# Altium schematic sheet MS20_schematic.SchDoc(MS20_schematic)
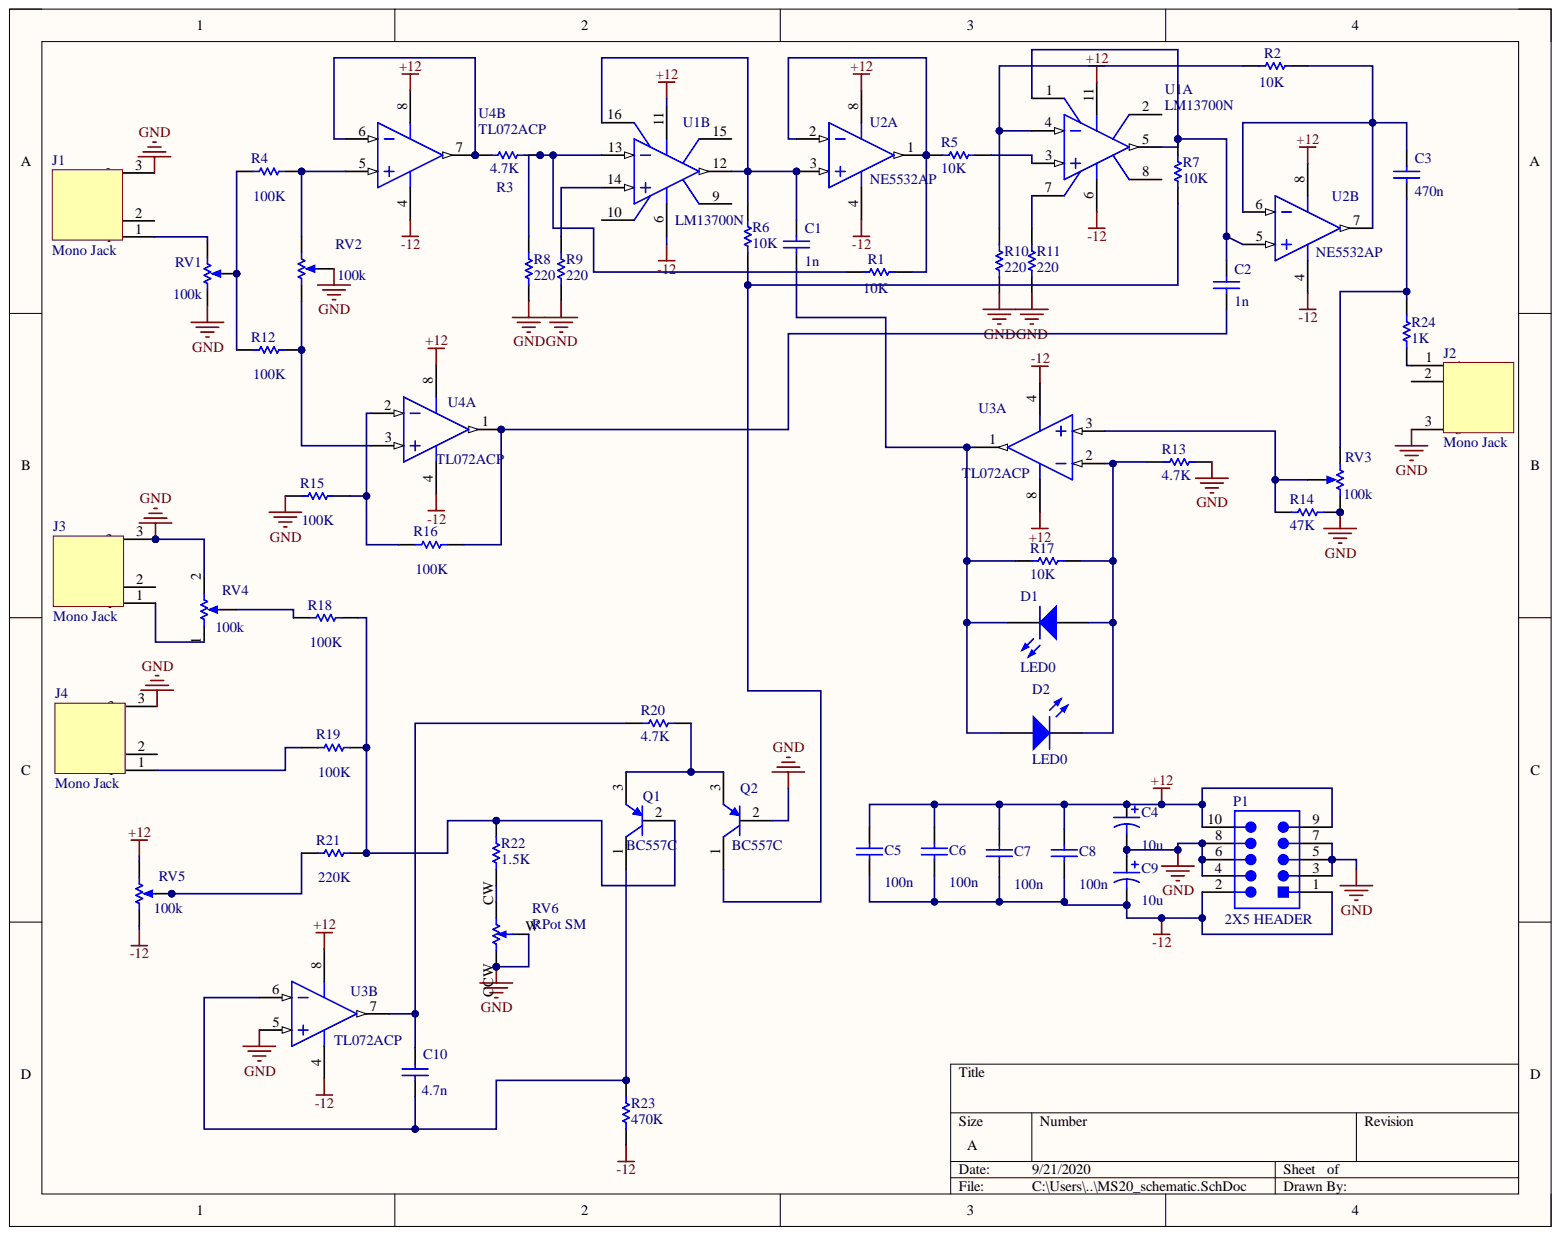
[diagram p0: MS20_schematic.SchDoc]

## Parts
C1  value 1n  [RAD-0.3]  (hidden comment: Cap)
C2  value 1n  [RAD-0.3]  (hidden comment: Cap)
C3  value 470n  [RAD-0.3]  (hidden comment: Cap)
C4  value 10u  [RB7.6-15]  (hidden comment: Cap Pol1)
C5  value 100n  [RAD-0.3]  (hidden comment: Cap)
C6  value 100n  [RAD-0.3]  (hidden comment: Cap)
C7  value 100n  [RAD-0.3]  (hidden comment: Cap)
C8  value 100n  [RAD-0.3]  (hidden comment: Cap)
C9  value 10u  [RB7.6-15]  (hidden comment: Cap Pol1)
C10  value 4.7n  [RAD-0.3]  (hidden comment: Cap)
D1  LED0  [LED-0]
D2  LED0  [LED-0]
J1  Mono Jack  [MONO_Jack]
J2  Mono Jack  [MONO_Jack]
J3  Mono Jack  [MONO_Jack]
J4  Mono Jack  [MONO_Jack]
P1  2X5 HEADER  [TECO-1-1634688-0-10_V]
Q1  BC557C  [ONSC-TO-92-3-29-11]
Q2  BC557C  [ONSC-TO-92-3-29-11]
R1  value 10K  [AXIAL-0.3]  (hidden comment: Res1)
R2  value 10K  [AXIAL-0.3]  (hidden comment: Res1)
R3  value 4.7K  [AXIAL-0.3]  (hidden comment: Res1)
R4  value 100K  [AXIAL-0.3]  (hidden comment: Res1)
R5  value 10K  [AXIAL-0.3]  (hidden comment: Res1)
R6  value 10K  [AXIAL-0.3]  (hidden comment: Res1)
R7  value 10K  [AXIAL-0.3]  (hidden comment: Res1)
R8  value 220  [AXIAL-0.3]  (hidden comment: Res1)
R9  value 220  [AXIAL-0.3]  (hidden comment: Res1)
R10  value 220  [AXIAL-0.3]  (hidden comment: Res1)
R11  value 220  [AXIAL-0.3]  (hidden comment: Res1)
R12  value 100K  [AXIAL-0.3]  (hidden comment: Res1)
R13  value 4.7K  [AXIAL-0.3]  (hidden comment: Res1)
R14  value 47K  [AXIAL-0.3]  (hidden comment: Res1)
R15  value 100K  [AXIAL-0.3]  (hidden comment: Res1)
R16  value 100K  [AXIAL-0.3]  (hidden comment: Res1)
R17  value 10K  [AXIAL-0.3]  (hidden comment: Res1)
R18  value 100K  [AXIAL-0.3]  (hidden comment: Res1)
R19  value 100K  [AXIAL-0.3]  (hidden comment: Res1)
R20  value 4.7K  [AXIAL-0.3]  (hidden comment: Res1)
R21  value 220K  [AXIAL-0.3]  (hidden comment: Res1)
R22  value 1.5K  [AXIAL-0.3]  (hidden comment: Res1)
R23  value 470K  [AXIAL-0.3]  (hidden comment: Res1)
R24  value 1K  [AXIAL-0.3]  (hidden comment: Res1)
RV1  value 100k  [VR5]  (hidden comment: RPot)
RV2  value 100k  [VR5]  (hidden comment: RPot)
RV3  value 100k  [VR5]  (hidden comment: RPot)
RV4  value 100k  [VR5]  (hidden comment: RPot)
RV5  value 100k  [VR5]  (hidden comment: RPot)
RV6  RPot SM  [POT4MM-2]
U1  LM13700N  [NFG0016A]  (parts A,B)
U2  NE5532AP  [P0008A]  (parts A,B)
U3  TL072ACP  [P0008A]  (parts A,B)
U4  TL072ACP  [P0008A]  (parts A,B)

## Nets
+12: C4.1 C5.1 C6.1 C7.1 C8.1 P1.9 P1.10 RV5.2 U1.11 U2.8 U3.8 U4.8
-12: C5.2 C6.2 C7.2 C8.2 C9.2 P1.1 P1.2 R23.2 RV5.1 U1.6 U2.4 U3.4 U4.4
GND: C4.2 C9.1 J1.3 J2.3 J3.3 J4.3 P1.3 P1.4 P1.5 P1.6 P1.7 P1.8 Q2.2 R8.1 R9.1 R10.1 R11.1 R13.1 R14.1 R15.1 RV1.1 RV2.3 RV3.2 RV4.2 RV6.CCW RV6.W U3.5
NetC1_1: C1.1 R6.2 U1.12 U1.16 U2.3
NetC1_2: C1.2 D1.2 D2.1 R17.2 U3.1
NetC2_1: C2.1 R7.2 U1.1 U1.5 U2.5
NetC2_2: C2.2 R16.2 U4.1
NetC3_1: C3.1 R2.1 U2.6 U2.7
NetC3_2: C3.2 R24.2 RV3.1
NetC10_1: C10.1 R20.2 U3.7
NetC10_2: C10.2 Q1.1 R23.1 U3.6
NetD1_1: D1.1 D2.2 R13.2 R17.1 U3.2
NetJ1_1: J1.1 RV1.2
NetJ2_1: J2.1 R24.1
NetJ3_1: J3.1 RV4.1
NetJ4_1: J4.1 R19.1
NetQ1_2: Q1.2 R18.2 R19.2 R21.2 R22.2
NetQ1_3: Q1.3 Q2.3 R20.1
NetQ2_1: Q2.1 R6.1 R7.1
NetR1_1: R1.1 R5.2 U2.1 U2.2
NetR1_2: R1.2 R3.1 R8.2 U1.13
NetR2_2: R2.2 R10.2 U1.4
NetR3_2: R3.2 U4.6 U4.7
NetR4_1: R4.1 R12.1 RV1.3
NetR4_2: R4.2 RV2.2 U4.5
NetR5_1: R5.1 U1.3
NetR9_2: R9.2 U1.14
NetR11_2: R11.2 U1.7
NetR12_2: R12.2 RV2.1 U4.3
NetR14_2: R14.2 RV3.3 U3.3
NetR15_2: R15.2 R16.1 U4.2
NetR18_1: R18.1 RV4.3
NetR21_1: R21.1 RV5.3
NetR22_1: R22.1 RV6.CW

Not connected: J1 2; J2 2; J3 2; J4 2; U1 2 8 9 10 15

## Library data of the parts
C1-C3 C5-C8 C10 = Cap: Capacitor; Component Kind: Standard; LatestRevisionDate: 17-Jul-2002; LatestRevisionNote: Re-released for DXP Platform.; Library Name: Miscellaneous Devices.IntLib; PackageDescription: Radial Cap, Thru-Hole; 2 Leads; 0.3 in Pin Spacing; PackageReference: RAD-0.3; Published: 8-Jun-2000; Signal Integrity: Cap; Simulation: CAP; Publisher: Altium Limited
C4 C9 = Cap Pol1: Polarized Capacitor (Radial); Component Kind: Standard; LatestRevisionDate: 17-Jul-2002; LatestRevisionNote: Re-released for DXP Platform.; Library Name: Miscellaneous Devices.IntLib; PackageDescription: Polarized Capacitor (Radial); 2 Leads; PackageReference: RB7.6-15; Published: 8-Jun-2000; Signal Integrity: Cap Pol; Simulation: CAP; Publisher: Altium Limited
D1 D2 = LED0: Typical INFRARED GaAs LED; Component Kind: Standard; LatestRevisionDate: 08-Jul-2005; LatestRevisionNote: Mech Layer 1 removed.; Library Name: Miscellaneous Devices.IntLib; PackageDescription: LED; 2 Leads; PackageReference: LED-0; Published: 8-Jun-2000; Simulation: LED0; Publisher: Altium Limited
J1-J4 = 4832.2211: Phone Connectors Audio Socket 3.5MM 2P SCR; Manufacturer: Schurter; Body Material: Metal; Current Rating: 500mA; Gender: Female; Max Voltage Rating (AC): 30V; Number of Contacts: 2; Number of Ports: 1; Shielding: Shielded; Case/Package: Metal; Category: Audio / Video Connectors; Component Kind: Standard; ComponentLink1Description: https://datasheet.ciiva.com/25289/1580985-25289573.pdf?src-supplier=Newark; ComponentLink1URL: https://datasheet.ciiva.com/25289/1580985-25289573.pdf?src-supplier=Newark; ComponentLink2Description: https://datasheet.ciiva.com/12540/typ-4832-2211-58787-12540719.pdf?src-supplier=Mouser; ComponentLink2URL: https://datasheet.ciiva.com/12540/typ-4832-2211-58787-12540719.pdf?src-supplier=Mouser; ComponentLink3Description: https://datasheet.ciiva.com/1086/1580985-1086284.pdf?src-supplier=Element14; ComponentLink3URL: https://datasheet.ciiva.com/1086/1580985-1086284.pdf?src-supplier=Element14; Contact Plating: Silver; Depth: 9mm; Diameter: 3.5mm; Length: 10.5mm; Library Name: Schlib1.SchLib; Manufacturer Part Number: 4832.2211; Material: Plastic; Max Current Rating: 500mA; Max Operating Temperature: 70°C; Min Operating Temperature: -20°C; Mount: Through Hole,Panel; Number of Terminals: 3; Orientation: Straight; Package Quantity: 500; Packaging: Bulk; Pricing 1: 1=1.50000, 50=1.38500, 100=1.13400, 500=0.94200, 1000=0.93840 (USD); REACH SVHC: No SVHC; RoHS: Yes; Stock 1: 363; Supplier 1: Digi-Key; Supplier Part Number 1: 486-3418-ND; Termination: Solder; Weight: 0.077603oz
P1 = CMP-2000-05854-1: Male Header, Pitch 2.54 mm, 2 x 5 Postion, Height 9.15 mm, Tail Length 3.2 mm, -40 to 105 degC, RoHS, Bulk; Manufacturer: TE Connectivity; PartNumber: 1-1634688-0; Contact Termination Type: ThroughHole; No. of Contacts: 10Contacts; No. of Positions: 10; No. of Rows: 2; Package Version: Rev. C2, 02/2011; Pitch: 2.54mm; SVHC: ToBeAdvised; Component Kind: Standard; Contact Material: Brass; Contact Plating: GoldPlatedContacts; Datasheet URL: https://datasheet.ciiva.com/6831/eng-cd-1634688-c2-6831201.pdf; Datasheet Version: Rev. C2, 02/2011; Gender: Header; Library Name: Altium Content Vault; Manufacturer URL: http://www.te.com/; Mounting Technology: ThroughHole; Orientation: Straight; Package Description: 10-Pin Connector, Body 20.35 x 8.7 mm, Pitch 2.54 mm; Package Reference: 1-1634688-0; Package URL: https://datasheet.ciiva.com/6831/eng-cd-1634688-c2-6831201.pdf; Pitch Spacing: 2.54mm; Product Range: -
Q1 Q2 = CMP-1048-01449-1: Amplifier Transistor, PNP Silicon, 3-Pin TO-92, Bulk Box; Manufacturer: On Semiconductor; IC Continuous (A): -0.1; Component Kind: Standard; ComponentLink1Description: Manufacturer URL; ComponentLink1URL: http://www.onsemi.com/; ComponentLink2Description: Datasheet; ComponentLink2URL: http://www.onsemi.com/pub_link/Collateral/BC556B-D.PDF; ComponentLink3Description: Package Specification; ComponentLink3URL: http://www.onsemi.cn/pub_link/Collateral/2N6426-D.PDF; DatasheetVersion: Rev. 3; Library Name: Altium Content Vault; Mounting Technology: Through Hole; PackageDescription: 3-Pin Transistor Outline, Body 4.825 x 3.685 mm, Pitch 1.27 mm; PackageReference: TO-92-3-29-11_AM_SL; PackageVersion: Rev. AM; Packing: Bulk Box; PartNumber: BC557C; Polarity: PNP; RoHS: FALSE
R1-R24 = Res1: Resistor; Component Kind: Standard; LatestRevisionDate: 17-Jul-2002; LatestRevisionNote: Re-released for DXP Platform.; Library Name: Miscellaneous Devices.IntLib; PackageDescription: Axial Device, Thru-Hole; 2 Leads; 0.3 in Pin Spacing; PackageReference: AXIAL-0.3; Published: 8-Jun-2000; Signal Integrity: Res; Simulation: RESISTOR; Publisher: Altium Limited
RV1-RV5 = RPot: Potentiometer; Set Position: 0.5; Sim Note: Enter the Resistance value and the set point into parameter fields.; Component Kind: Standard; LatestRevisionDate: 17-Jul-2002; LatestRevisionNote: Re-released for DXP Platform.; Library Name: Miscellaneous Devices.IntLib; PackageDescription: Variable Resistor, Thru-Hole; 3 Leads; PackageReference: VR5; Published: 8-Jun-2000; Simulation: POT; Publisher: Altium Limited
RV6 = RPot SM: Square Trimming Potentiometer; Component Kind: Standard; ComponentLink1Description: Manufacturer Link; ComponentLink1URL: http://bourns.com/2/search.html; DatasheetVersion: Oct-2003; Library Name: Miscellaneous Devices.IntLib; PackageDescription: Square Trimming Potentiometer; PackageReference: POT4MM-2; Published: 17-Dec-2003; Publisher: Altium Limited
U1 = CMP-0055-00179-3: Dual Operational Transconductance Amplifier with Linearizing Diodes and Buffers, 16-pin MDIP; Manufacturer: Texas Instruments; CompType: Amplifiers; PackageTopMarking: NSUZXYYTTE#*LM13700N; PowerWise: N; Code_JEDEC: MS-001-BB; Component Kind: Standard; ComponentLink1Description: Datasheet URL; ComponentLink1URL: http://www.ti.com/general/docs/lit/getliterature.tsp?genericPartNumber=LM13700&fileType=pdf; Library Name: Altium Content Vault; Package Reference: NFG0016A; PackageDescription: MDIP, .750 x .250 x .130in, 16 Lead, .100in Pitch; PinCount: 16; RoHS: N
U2 = CMP-0017-00921-2: Dual Low-Noise Operational Amplifier, 10 to 30 V, 0 to 70 degC, 8-pin DIP (P8), Pb-Free (RoHS); Manufacturer: Texas Instruments; CMRR(Min)(dB): 70; GBW(Typ)(MHz): 10; IIB(Max)(pA): 800000; Iq per channel(Max)(mA): 4; Number of Channels: 2; Slew Rate(Typ)(V/us): 9; Sub Family: General Purpose Amplifier, High Supply Voltage Amplifier (>=30V), Low Noise Amp (<=10nV/rtHz); Total Supply Voltage(Max)(+5V is 5, +/-5V is 10): 30; Vn at 1kHz(Typ)(nV/rtHz): 5; Code_JEDEC: MS-001; Component Kind: Standard; ComponentLink1Description: Manufacturer URL; ComponentLink1URL: http://www.ti.com/; ComponentLink2Description: Datasheet; ComponentLink2URL: http://www.ti.com/lit/ds/symlink/ne5532a.pdf; ComponentLink3Description: Package Specification; ComponentLink3URL: http://www.ti.com/litv/pdf/mpdi001b; DatasheetVersion: SLOS075I; Eco-Plan: Pb-Free (RoHS); Library Name: Altium Content Vault; Mounting Technology: Through Hole; PackageDescription: 8-Pin Plastic In-Line, Body 9.59 x 6.35 mm, Pitch 2.54 mm; PackageReference: P0008A; PackageVersion: 4040082/E, 04/2010; PartNumber: NE5532AP; Total Supply Voltage(Min)(+5V is 5, +/-5V is 10): 10; Vio (25C)(Max)(mV): 4
U3 U4 = CMP-0017-00929-2: Low-Noise JFET-Input Operational Amplifier, 7 to 36 V, 0 to 70 degC, 8-pin DIP (P8), Pb-Free (RoHS); Manufacturer: Texas Instruments; CMRR(Min)(dB): 75; GBW(Typ)(MHz): 3; IIB(Max)(pA): 200; Iq per channel(Max)(mA): 2.5; Number of Channels: 2; Offset Drift(Typ)(uV/C): 18; Rating: Catalog; Slew Rate(Typ)(V/us): 13; Sub Family: General Purpose Amplifier, High Supply Voltage Amplifier (>=30V); Total Supply Voltage(Max)(+5V is 5, +/-5V is 10): 36; Total Supply Voltage(Min)(+5V is 5, +/-5V is 10): 7; Vio (25C)(Max)(mV): 6; Code_JEDEC: MS-001; Component Kind: Standard; ComponentLink1Description: Manufacturer URL; ComponentLink1URL: http://www.ti.com/; ComponentLink2Description: Datasheet; ComponentLink2URL: http://www.ti.com/lit/ds/symlink/tl072a.pdf; ComponentLink3Description: Package Specification; ComponentLink3URL: http://www.ti.com/litv/pdf/mpdi001b; DatasheetVersion: SLOS080J; Eco-Plan: Pb-Free (RoHS); Library Name: Altium Content Vault; Mounting Technology: Through Hole; PackageDescription: 8-Pin Plastic In-Line, Body 9.59 x 6.35 mm, Pitch 2.54 mm; PackageReference: P0008A; PackageVersion: 4040082/E, 04/2010; PartNumber: TL072ACP; Vn at 1kHz(Typ)(nV/rtHz): 18
